# Altium schematic sheet GammaMRICAB_2 - Power Management.SchDoc
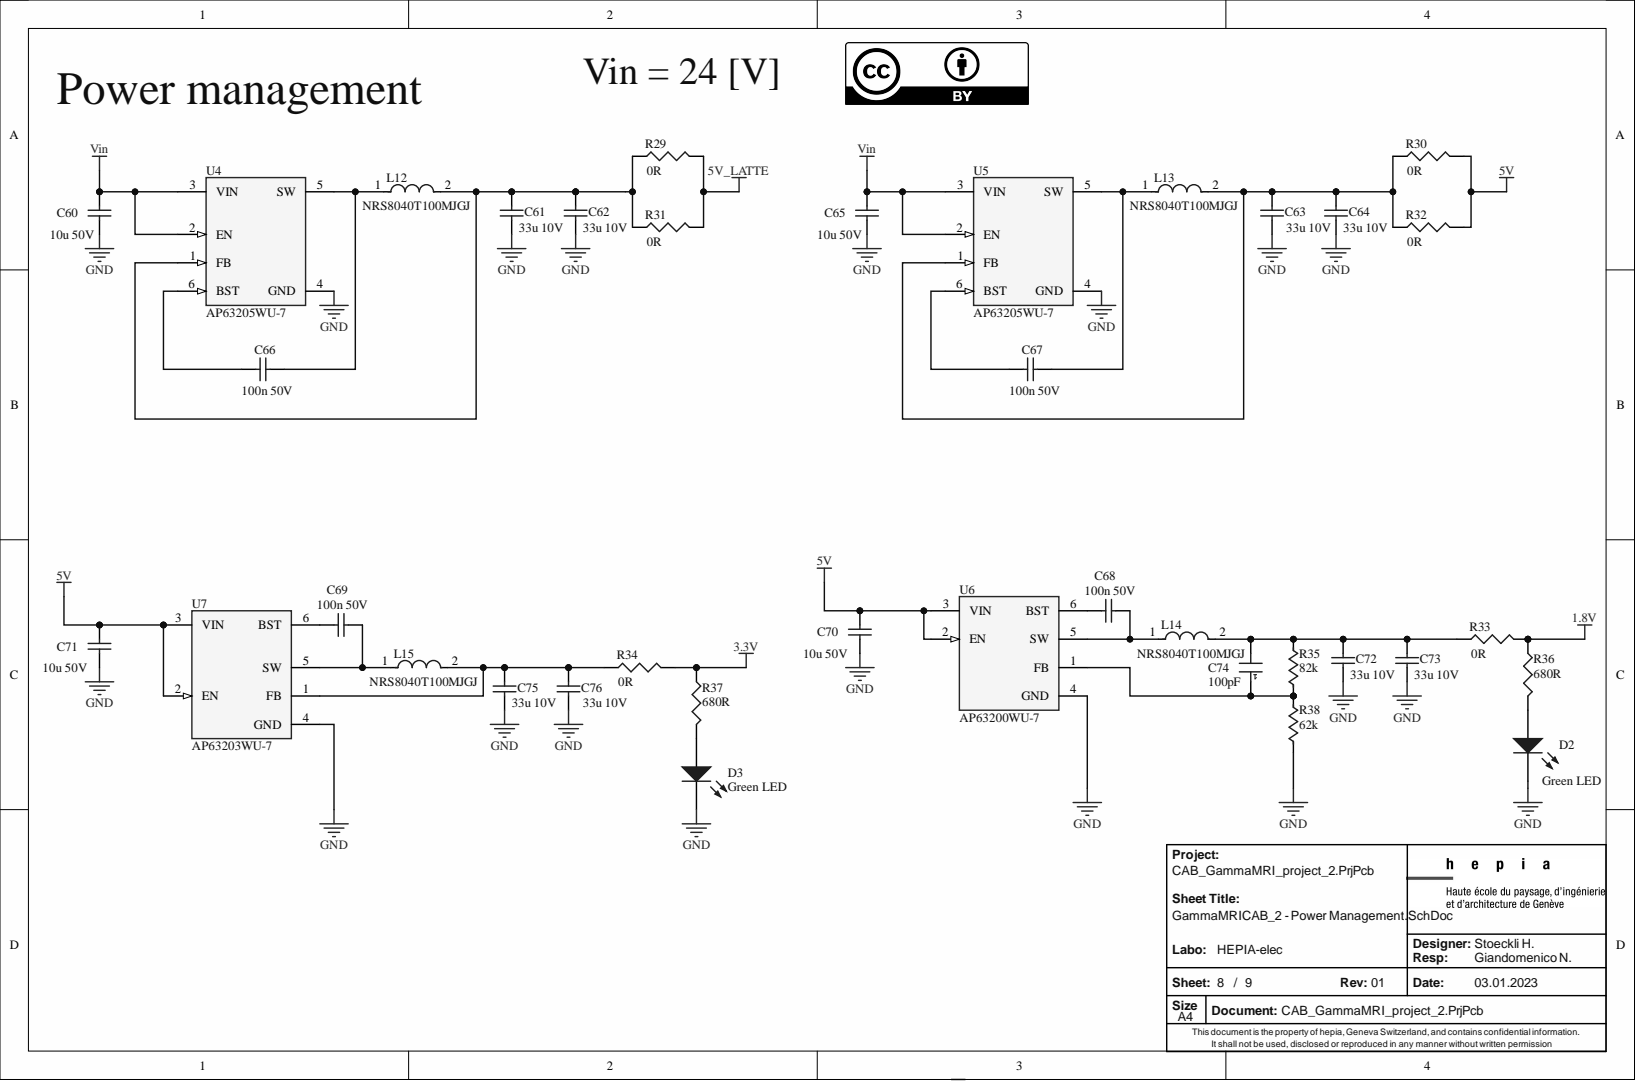
[diagram p0: GammaMRICAB_2 - Power Management.SchDoc]

## Parts
C60  10u 50V  [INDC3216]
C61  33u 10V  [6-0805_M]
C62  33u 10V  [6-0805_M]
C63  33u 10V  [6-0805_M]
C64  33u 10V  [6-0805_M]
C65  10u 50V  [INDC3216]
C66  100n 50V  [1608[0603]]
C67  100n 50V  [1608[0603]]
C68  100n 50V  [1608[0603]]
C69  100n 50V  [1608[0603]]
C70  10u 50V  [INDC3216]
C71  10u 50V  [INDC3216]
C72  33u 10V  [6-0805_M]
C73  33u 10V  [6-0805_M]
C74  100pF  [1608[0603]]
C75  33u 10V  [6-0805_M]
C76  33u 10V  [6-0805_M]
D2  Green LED  [0402-A]
D3  Green LED  [0402-A]
L12  NRS8040T100MJGJ  [FP-NR8040-H_4_0-MFG]
L13  NRS8040T100MJGJ  [FP-NR8040-H_4_0-MFG]
L14  NRS8040T100MJGJ  [FP-NR8040-H_4_0-MFG]
L15  NRS8040T100MJGJ  [FP-NR8040-H_4_0-MFG]
R29  0R  [C1210_L]
R30  0R  [C1210_L]
R31  0R  [C1210_L]
R32  0R  [C1210_L]
R33  0R  [C1210_L]
R34  0R  [C1210_L]
R35  82k  [1608[0603]]
R36  680R  [0402]
R37  680R  [0402]
R38  62k  [1608[0603]]
U4  AP63205WU-7  [FP-TSOT26-MFG]
U5  AP63205WU-7  [FP-TSOT26-MFG]
U6  AP63200WU-7  [FP-TSOT26-MFG]
U7  AP63203WU-7  [FP-TSOT26-MFG]

## Nets
1.8V: R33.2 R36.1
3.3V: R34.2 R37.1
5V: C70.2 C71.2 R30.2 R32.2 U6.2(EN) U6.3(VIN) U7.2(EN) U7.3(VIN)
5V_LATTE: R29.2 R31.2
GND: C60.1 C61.2 C62.2 C63.2 C64.2 C65.1 C70.1 C71.1 C72.2 C73.2 C75.2 C76.2 D2.2 D3.2 R38.2 U4.4(GND) U5.4(GND) U6.4(GND) U7.4(GND)
Vin: C60.2 C65.2 U4.2(EN) U4.3(VIN) U5.2(EN) U5.3(VIN)
NetC61_1: C61.1 C62.1 L12.2 R29.1 R31.1 U4.1(FB)
NetC63_1: C63.1 C64.1 L13.2 R30.1 R32.1 U5.1(FB)
NetC66_1: C66.1 U4.6(BST)
NetC66_2: C66.2 L12.1 U4.5(SW)
NetC67_1: C67.1 U5.6(BST)
NetC67_2: C67.2 L13.1 U5.5(SW)
NetC68_1: C68.1 U6.6(BST)
NetC68_2: C68.2 L14.1 U6.5(SW)
NetC69_1: C69.1 U7.6(BST)
NetC69_2: C69.2 L15.1 U7.5(SW)
NetC72_1: C72.1 C73.1 C74.2 L14.2 R33.1 R35.1
NetC74_1: C74.1 R35.2 R38.1 U6.1(FB)
NetC75_1: C75.1 C76.1 L15.2 R34.1 U7.1(FB)
NetD2_1: D2.1 R36.2
NetD3_1: D3.1 R37.2

## Library data of the parts
C60 C65 C70 C71 = Cap: Capacitor 10uF C1206 X6S 50V; Component Kind: Standard; Library Name: Miscellaneous Devices.IntLib; Signal Integrity: Cap; Simulation: CAP; Supplier1: Digikey; Supplier1PN1: 1276-6736-1-ND
C61-C64 C72 C73 C75 C76 = Cap: Capacitor 33uF C0805 X5R 10V; Component Kind: Standard; Library Name: Miscellaneous Devices.IntLib; Signal Integrity: Cap; Simulation: CAP; Supplier1: Digikey; Supplier1PN1: 445-8238-1-ND
C66-C69 = Cap: CAP CER 0.1UF 50V Y5V 0603; Component Kind: Standard; Library Name: Miscellaneous Devices.IntLib; Signal Integrity: Cap; Simulation: CAP; Supplier1: Digikey; Supplier1PN1: 311-1343-1-ND
C74 = D_CAP-52: Boite Labo 0603: Ceramic Cap, 100pF, 10%, 50V, CAP_0603H0.8; Database Table Name: D_Capacitors$; Component Kind: Standard; Supplier1: Mouser; Library Name: Database_Altium_Hepia_Elec.DbLib
D2 D3 = LED2: GREEN LED, 0402; Component Kind: Standard; Library Name: Miscellaneous Devices.IntLib; Simulation: LED2; Supplier1: Digikey; Supplier1PN1: 732-11992-1-ND
L12-L15 = NRS8040T100MJGJ: Shielded Wirewound Inductor 10uH 20% 3.5A 44.2mΩ SMD; Component Kind: Standard; Supplier1: Digikey; Supplier1PN1: 587-2972-1-ND; Library Name: CAB_SCH_LIB.SchLib
R29-R34 = Res3: Resistor 0Ohm 1206; Component Kind: Standard; Library Name: Miscellaneous Devices.IntLib; Signal Integrity: Res; Simulation: RESISTOR; Supplier1: Digikey; Supplier1PN1: RMCF1206ZT0R00CT-ND
R35 = D_RES-120: Thick Film Resistor, Boite Labo 0603, 82k 1%; Database Table Name: D_Resistors$; Component Kind: Standard; Supplier1: Digikey; Library Name: Database_Altium_Hepia_Elec.DbLib
R36 R37 = Res3: Resistor 680Ohm 5% 0402; Component Kind: Standard; Library Name: Miscellaneous Devices.IntLib; Signal Integrity: Res; Simulation: RESISTOR; Supplier1: Digikey; Supplier1PN1: A129625CT-ND
R38 = D_RES-120: Thick Film Resistor, Boite Labo 0603, 62k 1%; Database Table Name: D_Resistors$; Component Kind: Standard; Supplier1: Digikey; Library Name: Database_Altium_Hepia_Elec.DbLib
U4 U5 = CMP-12757-000001-1: IC REG BUCK 5V 2A TSOT26; Component Kind: Standard; Library Name: Altium Content Vault; Supplier1: Digikey; Supplier1PN1: AP63205WU-7DICT-ND
U6 = CMP-12757-000010-1: IC REG BUCK ADJUSTABLE 2A TSOT26; Component Kind: Standard; Library Name: Altium Content Vault; Supplier1: Digikey; Supplier1PN1: AP63200WU-7DICT-ND
U7 = CMP-12757-000006-1: IC REG BUCK 3.3V 2A TSOT26; Component Kind: Standard; Library Name: Altium Content Vault; Supplier1: Digikey; Supplier1PN1: AP63203WU-7DICT-ND
